# Altium schematic sheet pi_current.SchDoc
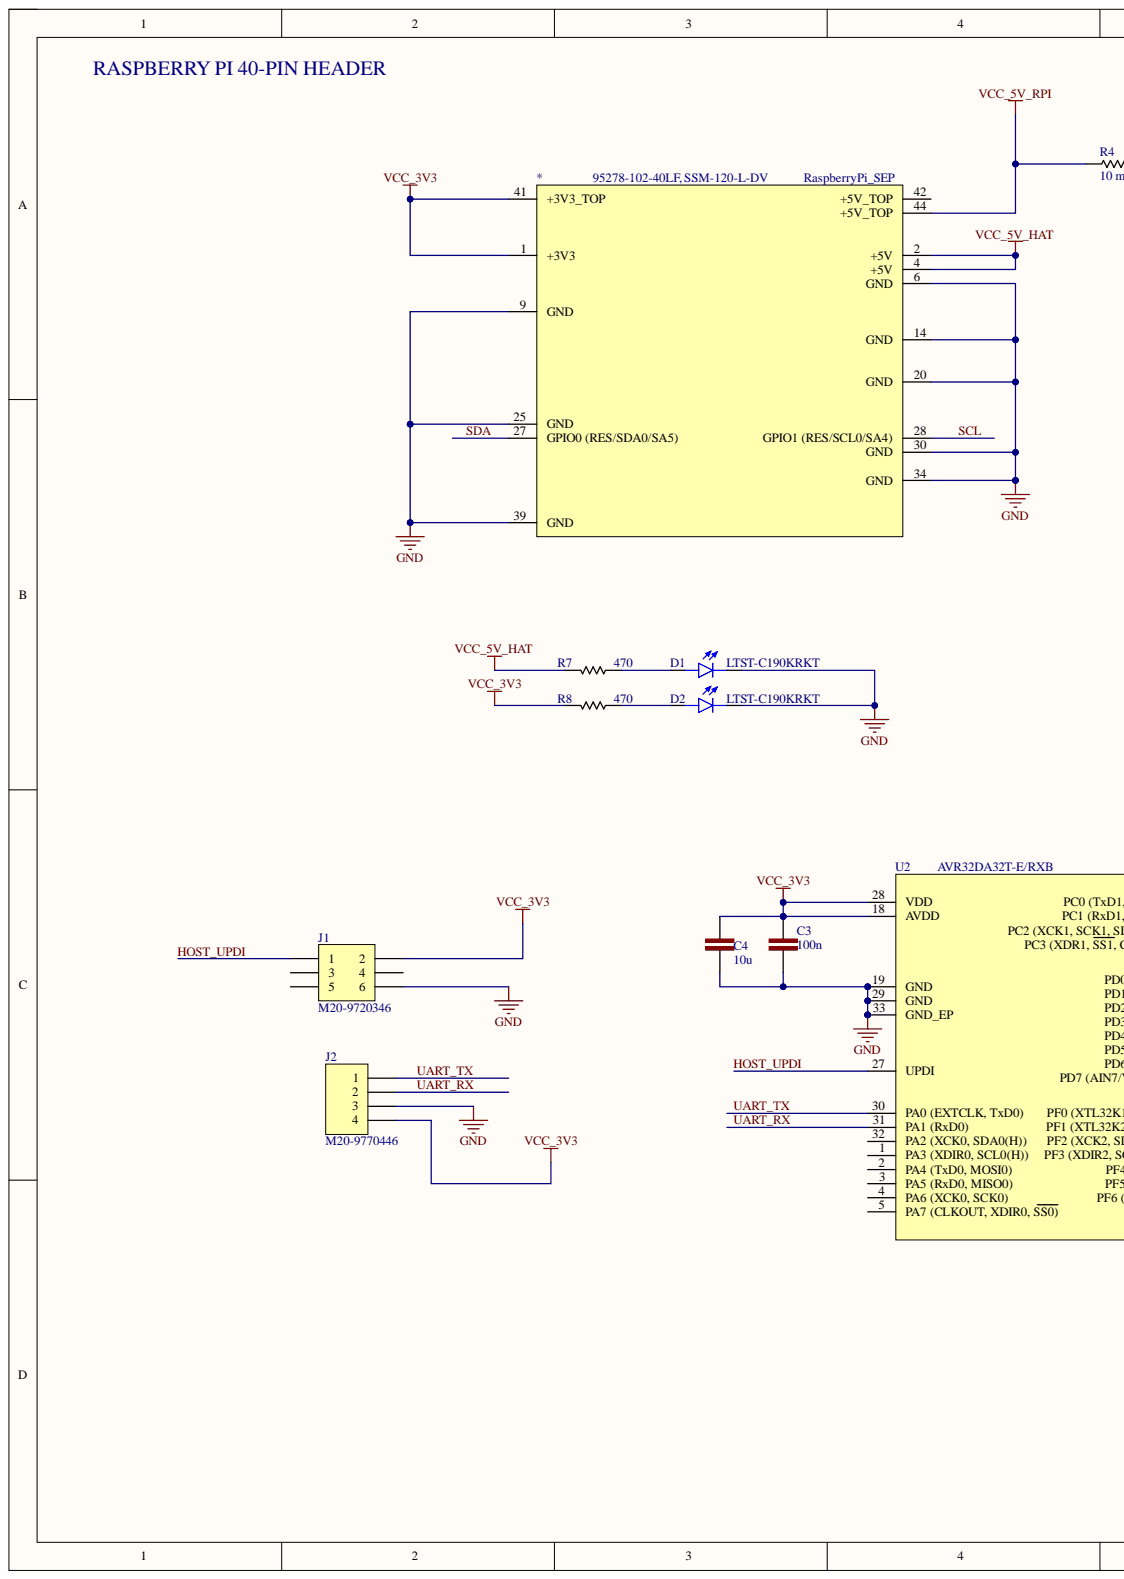
[diagram p0: pi_current.SchDoc, left]
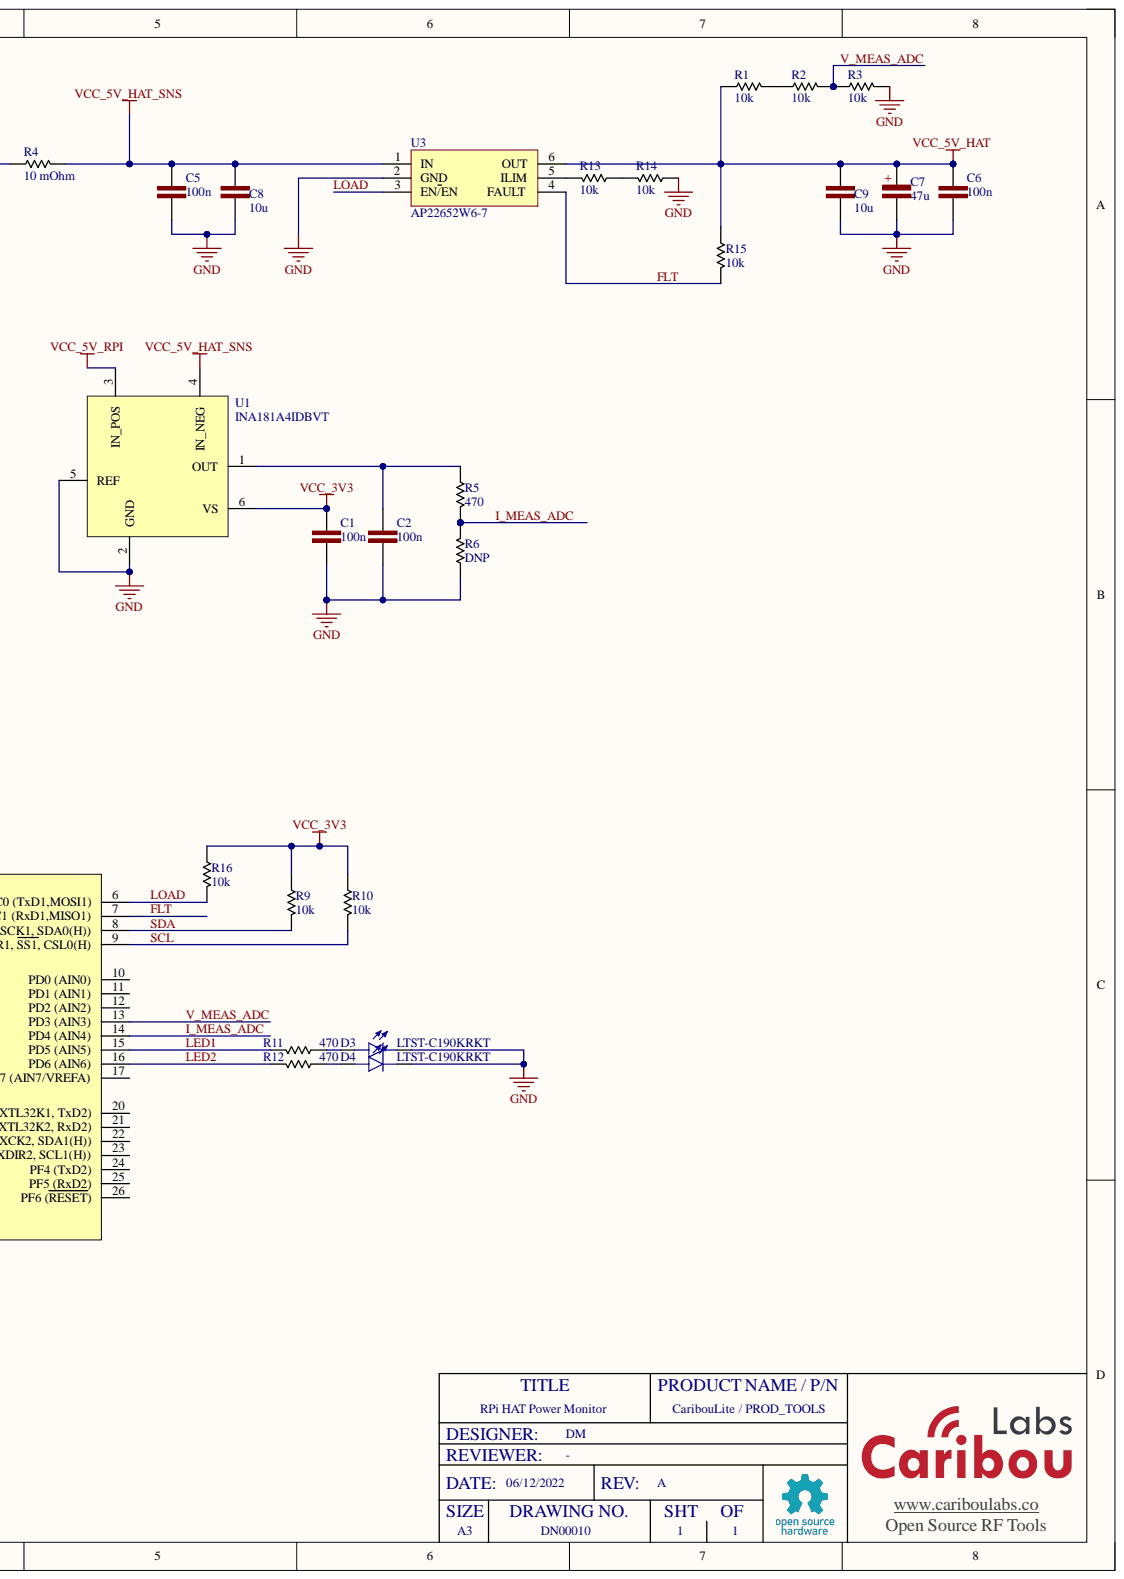
[diagram p1: pi_current.SchDoc, right]

## Parts
*  RaspberryPi_SEP  Manu. P/N: 95278-102-40LF, SSM-120-L-DV  [rpi_4_SEP]
C1  100n  [MURATA 0402]
C2  100n  [MURATA 0402]
C3  100n  [MURATA 0402]
C4  10u  [MURATA 0402]
C5  100n  [MURATA 0402]
C6  100n  [MURATA 0402]
C7  47u  [CAPMP7343X28N]
C8  10u  [MURATA 0402]
C9  10u  [MURATA 0402]
D1  LTST-C190KRKT  [LEDC1608X35N]
D2  LTST-C190KRKT  [LEDC1608X35N]
D3  LTST-C190KRKT  [LEDC1608X35N]
D4  LTST-C190KRKT  [LEDC1608X35N]
J1  M20-9720346  [M20-9720346]
J2  M20-9770446  [M20-9770446]
R1  10k  [PANASONIC 0402]
R2  10k  [PANASONIC 0402]
R3  10k  [PANASONIC 0402]
R4  10 mOhm  [RES_ERJ6CW]
R5  470  [PANASONIC 0402]
R6  DNP  [PANASONIC 0402]  (no BOM)
R7  470  [PANASONIC 0402]
R8  470  [PANASONIC 0402]
R9  10k  [PANASONIC 0402]
R10  10k  [PANASONIC 0402]
R11  470  [PANASONIC 0402]
R12  470  [PANASONIC 0402]
R13  10k  [PANASONIC 0402]
R14  10k  [PANASONIC 0402]
R15  10k  [PANASONIC 0402]
R16  10k  [PANASONIC 0402]
U1  Manu. P/N: INA181A4IDBVT  [SOT95P280X145-6N]  (hidden comment: INA181A4IDBVR)
U2  AVR32DA32T-E/RXB  [QFN50P500X500X90_HS-33N]
U3  AP22652W6-7  [SOT95P280X145-6N]

## Nets
GND: *.6(GND) *.9(GND) *.14(GND) *.20(GND) *.25(GND) *.30(GND) *.34(GND) *.39(GND) C1.1 C2.1 C3.1 C4.1 C5.1 C6.1 C7.1 C8.1 C9.1 D1.C D2.C D3.C D4.C J1.6 J2.3 R3.2 R6.1 R14.1 U1.2(GND) U1.5(REF) U2.19(GND) U2.29(GND) U2.33(GND_EP) U3.2(GND)
VCC_3V3: *.1(+3V3) *.41(+3V3_TOP) C1.2 C3.2 C4.2 J1.2 J2.4 R8.2 R9.1 R10.1 R16.1 U1.6(VS) U2.18(AVDD) U2.28(VDD)
VCC_5V_HAT: *.2(+5V) *.4(+5V) C6.2 C7.2 C9.2 R1.1 R7.2 R15.1 U3.6(OUT)
VCC_5V_HAT_SNS: C5.2 C8.2 R4.2 U1.4(IN_NEG) U3.1(IN)
VCC_5V_RPI: *.44(+5V_TOP) R4.1 U1.3(IN_POS)
FLT: R15.2 U2.7(PC1_(RxD1,MISO1)) U3.4(FAULT)
HOST_UPDI: J1.1 U2.27(UPDI)
I_MEAS_ADC: R5.2 R6.2 U2.14(PD4_(AIN4))
LED1: R11.2 U2.15(PD5_(AIN5))
LED2: R12.2 U2.16(PD6_(AIN6))
LOAD: R16.2 U2.6(PC0_(TxD1,MOSI1)) U3.3(EN/EN)
SCL: *.28(GPIO1_(RES/SCL0/SA4)) R10.2 U2.9(PC3_(XDR1,_SS1,_CSL0(H))
SDA: *.27(GPIO0_(RES/SDA0/SA5)) R9.2 U2.8(PC2_(XCK1,_SCK1,_SDA0(H)))
UART_RX: J2.2 U2.31(PA1_(RxD0))
UART_TX: J2.1 U2.30(PA0_(EXTCLK,_TxD0))
V_MEAS_ADC: R2.2 R3.1 U2.13(PD3_(AIN3))
NetC2_2: C2.2 R5.1 U1.1(OUT)
NetD1_A: D1.A R7.1
NetD2_A: D2.A R8.1
NetD3_A: D3.A R11.1
NetD4_A: D4.A R12.1
NetR1_2: R1.2 R2.1
NetR13_1: R13.1 R14.2
NetR13_2: R13.2 U3.5(ILIM)

Not connected: * 42(+5V_TOP); J1 3 4 5; U2 1(PA3_(XDIR0,_SCL0(H))) 2(PA4_(TxD0,_MOSI0)) 3(PA5_(RxD0,_MISO0)) 4(PA6_(XCK0,_SCK0)) 5(PA7_(CLKOUT,_XDIR0,_SS0)) 10(PD0_(AIN0)) 11(PD1_(AIN1)) 12(PD2_(AIN2)) 17(PD7_(AIN7/VREFA)) 20(PF0_(XTL32K1,_TxD2)) 21(PF1_(XTL32K2,_RxD2)) 22(PF2_(XCK2,_SDA1(H))) 23(PF3_(XDIR2,_SCL1(H))) 24(PF4_(TxD2)) 25(PF5_(RxD2)) 26(PF6_(RESET)) 32(PA2_(XCK0,_SDA0(H)))

## Library data of the parts
C1-C3 C5 C6 = Capacitor: Multilayer Ceramic Capacitors MLCC - SMD/SMT 50V 0.1uF X7R 0402 10%; Initial Voltage: 0; Manu. P/N: GRT155R71H104KE01D; SRF: TBD [GHz]; Temp. Range: [-40, 125]; Component Kind: Standard; Library Name: CuSiP_Lib.IntLib; Signal Integrity: Guessed Model; Simulation: Model Name; Technology: X7R; Tolerance: 10%; VALUE: 100n; Vmax: 50V
C4 C8 C9 = Capacitor: Multilayer Ceramic Capacitors MLCC - SMD/SMT 10uF+/-20% 10V X5R 1 0402; Initial Voltage: 0; Manu. P/N: CL05A106MP6NUN8; SRF: TBD [GHz]; Temp. Range: [-40, 125]; Tolerance: 20%; Component Kind: Standard; Library Name: DM_Lib.IntLib; Simulation: Model Name; Technology: X5R; Value: 10u; Vmax: 10V
C7 = Capacitor Polarized: Tantalum Capacitors - Solid SMD 35V 47uF 2917 20% ESR=230mOhms; Initial Voltage: 0; Manu. P/N: T495X476M035ATE230; SRF: TBD [GHz]; Temp. Range: [-40, 125]; Tolerance: 5%; Component Kind: Standard; Library Name: DM_Lib.IntLib; Technology: Tantalum; Value: 47u; Vmax: 35V
D1-D4 = LTST-C190KRKT: Red 631nm LED Indication - Discrete 2V 0603; Component Kind: Standard; Library Name: CuSiP_Lib.IntLib; Manu. P/N: LTST-C190KRKT; Signal Integrity: Guessed Model
J1 = M20-9720346: Header Male 2X3; Component Kind: Standard; Library Name: DM_Lib.IntLib; Manu. P/N: M20-9720346
J2 = M20-9770446: Header Male 4X1; Component Kind: Standard; Library Name: DM_Lib.IntLib; Manu. P/N: M20-9770446
R1-R3 R9 R10 R13-R16 = Resistor: Thick Film Resistors - SMD 1/20watt 10Kohms 1% 200ppm; Manu. P/N: CRCW040210K0FKEDC; Power Rating: 50 mW (1/16 W); Temp. Ceoff.: 100 PPM / C; Component Kind: Standard; Library Name: DM_Lib.IntLib; Signal Integrity: Guessed Model; Simulation: Model Name; Tolerance: 1%; VALUE: 10k
R4 = Resistor: Manu. P/N: ERJ-6CWFR010V; Power Rating: 100 mW; Temp. Ceoff.: 0 PPM/C; Tolerance: 1%; Component Kind: Standard; Description: Resistor; Library Name: DM_Lib.IntLib; Simulation: Model Name; Value: 10 mOhm
R5 R7 R8 R11 R12 = Resistor: Thick Film Resistors - SMD 1/16watt 470ohms 1%; Manu. P/N: CRCW0402470RFKEDC; Power Rating: 62.5 mW (1/16 W); Temp. Ceoff.: 100 PPM / C; Component Kind: Standard; Library Name: CuSiP_Lib.IntLib; Signal Integrity: Guessed Model; Simulation: Model Name; Tolerance: 1%; VALUE: 470
R6 = Resistor: Power Rating: 62.5 mW (1/16 W); Temp. Ceoff.: 100 PPM / C; Tolerance: 1%; Description: DNP; Library Name: DM_Lib.IntLib; Manu. P/N: DNP; Signal Integrity: Guessed Model; Simulation: Model Name; VALUE: DNP
U1 = INA181A4IDBVR: 26V, bi-directional, 350kHz current sense amplifier 6-SOT-23 -40 to 125; Component Kind: Standard; Library Name: DM_Lib.IntLib
U2 = AVR32DA32T-E/RXB: 8-bit Microcontrollers - MCU 32 KB,32-pin,125C,VQFN; Component Kind: Standard; Library Name: DM_Lib.IntLib; Manu. P/N: AVR32DA32T-E/RXB
U3 = AP22652W6-7: Power Switch ICs - Power Distribution 1-Ch Precision Curr-Limit Load SW; Component Kind: Standard; Library Name: DM_Lib.IntLib; Manu. P/N: AP22652W6-7
* = RaspberryPi_SEP: Component Kind: Standard; Library Name: DM_Lib.IntLib
